# Altium schematic sheet TruckCape BeagleBone Headers v3.SchDoc
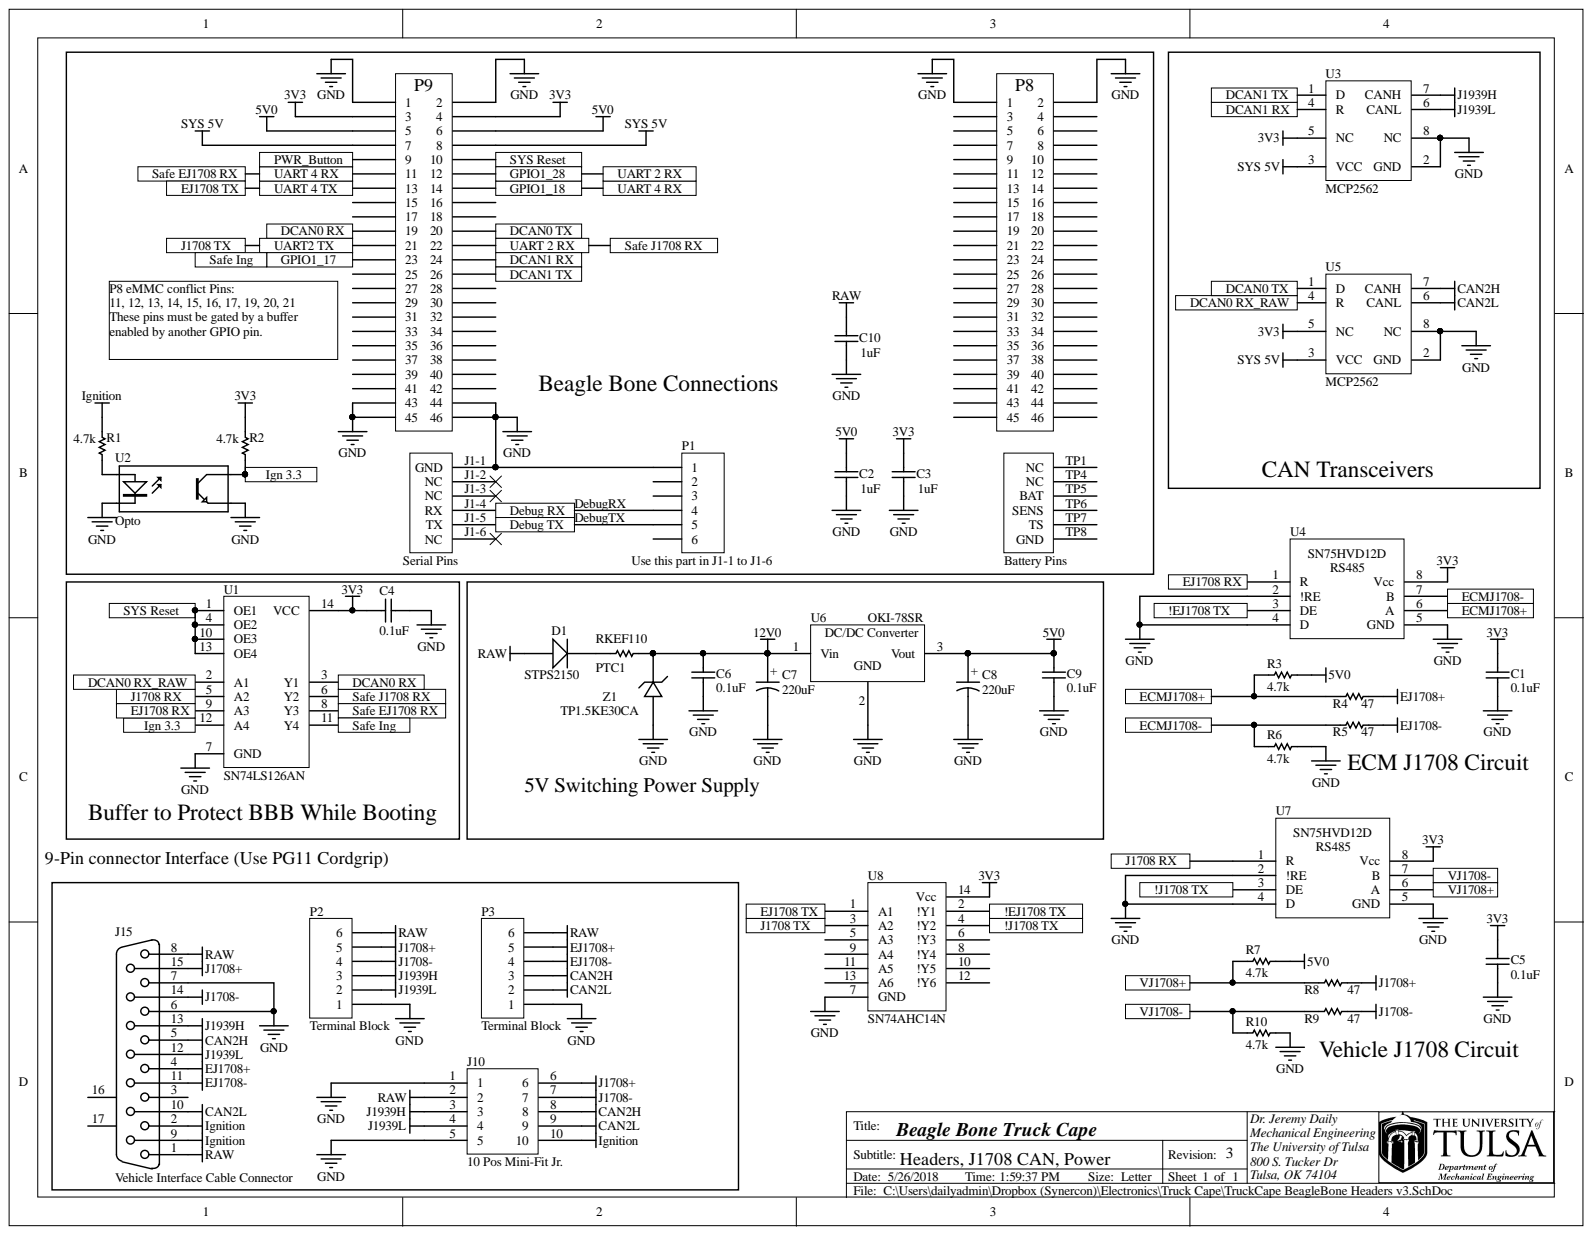
[diagram p0: TruckCape BeagleBone Headers v3.SchDoc]

## Parts
BeagleBoneBlack1  BeagleBoneBlack  [Beagleboneblack]  (value not drawn)
C1  0.1uF  [CAPR5-4X5]
C2  1uF  [CAPR5-4X5]
C3  1uF  [CAPR5-4X5]
C4  0.1uF  [CAPR5-4X5]
C5  0.1uF  [CAPR5-4X5]
C6  0.1uF  [CAPR5-4X5]
C7  220uF  [Elec. Cap 5 x 10mm]
C8  220uF  [Elec. Cap 5 x 10mm]
C9  0.1uF  [CAPR5-4X5]
C10  1uF  [CAPR5-4X5]
D1  STPS2150  [DO-41]
J10  10 Pos Mini-Fit Jr.  [10 pos Mini-Fit Jr. R/A Header]
J15  Vehicle Interface Cable Connector  [DB15]
P1  Use this part in J1-1 to J1-6  [HDR1X6]
P2  Terminal Block  [HDR1X6]
P3  Terminal Block  [HDR1X6]
PTC1  RKEF110  [PTC]
R1  4.7k  [Axial-0.4]
R2  4.7k  [Axial-0.4]
R3  4.7k  [Axial-0.4]
R4  47  [Axial-0.4]
R5  47  [Axial-0.4]
R6  4.7k  [Axial-0.4]
R7  4.7k  [Axial-0.4]
R8  47  [Axial-0.4]
R9  47  [Axial-0.4]
R10  4.7k  [Axial-0.4]
U1  SN74LS126AN  [N14A]
U2  Opto  [DIP-4]
U3  MCP2562  [SN65HVD232]
U4  SN75HVD12  [PDIP300-P8]
U5  MCP2562  [SN65HVD232]
U6  OKI-78SR  [OKI-78SR]
U7  SN75HVD12  [PDIP300-P8]
U8  SN74AHC14N  [N14A]
Z1  TP1.5KE30CA  [DIODE-0.7]

## Ports
!EJ1708 TX, !J1708 TX, DCAN0 RX, DCAN0 RX_RAW, DCAN0 TX, DCAN1 RX, DCAN1 TX, Debug RX, Debug TX, ECMJ1708+, ECMJ1708-, EJ1708 RX, EJ1708 TX, GPIO1_17, GPIO1_18, GPIO1_28, Ign 3.3, J1708 RX, J1708 TX, PWR_Button, Safe EJ1708 RX, Safe Ing, Safe J1708 RX, SYS Reset, UART2 TX, UART 2 RX, UART 4 RX, UART 4 TX, VJ1708+, VJ1708-

## Nets
3V3: BeagleBoneBlack1.3 BeagleBoneBlack1.4 C1.2 C3.1 C4.1 C5.2 R2.1 U1.14(VCC) U3.5(NC) U4.8(Vcc) U5.5(NC) U7.8(Vcc) U8.14(Vcc)
5V0: BeagleBoneBlack1.5 BeagleBoneBlack1.6 C2.1 C8.1 C9.2 R3.2 R7.2 U6.3
12V0: C6.2 C7.1 PTC1.2 U6.1 Z1.2
CAN2H: J10.8 J15.5 P3.3 U5.7(CANH)
CAN2L: J10.9 J15.10 P3.2 U5.6(CANL)
EJ1708+: J15.4 P3.5 R4.2
EJ1708-: J15.11 P3.4 R5.2
GND: BeagleBoneBlack1.1 BeagleBoneBlack1.2 BeagleBoneBlack1.43 BeagleBoneBlack1.44 BeagleBoneBlack1.45 BeagleBoneBlack1.46 BeagleBoneBlack1.J1-1 BeagleBoneBlack1.P1 BeagleBoneBlack1.P2 C1.1 C2.2 C3.2 C4.2 C5.1 C6.1 C7.2 C8.2 C9.1 C10.2 J10.1 J10.5 J15.6 J15.7 P1.1 P2.1 P3.1 R6.2 R10.2 U1.7(GND) U2.2 U2.3 U3.2(GND) U3.8(NC) U4.2(!RE) U4.4(D) U4.5(GND) U5.2(GND) U5.8(NC) U6.2 U7.2(!RE) U7.4(D) U7.5(GND) U8.7(GND) Z1.1
Ignition: J10.10 J15.2 J15.9 R1.1
J1708+: J10.6 J15.15 P2.5 R8.2
J1708-: J10.7 J15.14 P2.4 R9.2
J1939H: J10.3 J15.13 P2.3 U3.7(CANH)
J1939L: J10.4 J15.12 P2.2 U3.6(CANL)
RAW: C10.1 D1.1 J10.2 J15.1 J15.8 P2.6 P3.6
SYS 5V: BeagleBoneBlack1.7 BeagleBoneBlack1.8 U3.3(VCC) U5.3(VCC)
!EJ1708 TX: U4.3(DE) U8.2(!Y1) ; port !EJ1708 TX
!J1708 TX: U7.3(DE) U8.4(!Y2) ; port !J1708 TX
DCAN0 RX: BeagleBoneBlack1.19 U1.3(Y1) ; port DCAN0 RX
DCAN0 RX_RAW: U1.2(A1) U5.4(R) ; port DCAN0 RX_RAW
DCAN0 TX: BeagleBoneBlack1.20(DCAN0_TX) U5.1(D) ; port DCAN0 TX
DCAN1 RX: BeagleBoneBlack1.24(DCAN1_RX) U3.4(R) ; port DCAN1 RX
DCAN1 TX: BeagleBoneBlack1.26(DCAN1_TX) U3.1(D) ; port DCAN1 TX
DebugRX: BeagleBoneBlack1.J1-4(Debug_RX) P1.4 ; port Debug RX
DebugTX: BeagleBoneBlack1.J1-5(Debug_TX) P1.5 ; port Debug TX
EJ1708 RX: U1.9(A3) U4.1(R) ; port EJ1708 RX
EJ1708 TX: BeagleBoneBlack1.13 U8.1(A1) ; port EJ1708 TX, port UART 4 TX
GPIO1_17: BeagleBoneBlack1.23 U1.11(Y4) ; port GPIO1_17, port Safe Ing
GPIO1_18: BeagleBoneBlack1.11 BeagleBoneBlack1.14(GPIO1_18) U1.8(Y3) ; port GPIO1_18, port Safe EJ1708 RX, port UART 4 RX
GPIO1_28: BeagleBoneBlack1.12(GPIO1_28) BeagleBoneBlack1.22(UART_2_RX) U1.6(Y2) ; port GPIO1_28, port Safe J1708 RX, port UART 2 RX
Ign 3.3: R2.2 U1.12(A4) U2.4 ; port Ign 3.3
J1708 RX: U1.5(A2) U7.1(R) ; port J1708 RX
J1708 TX: BeagleBoneBlack1.21 U8.3(A2) ; port J1708 TX, port UART2 TX
PWR_Button: BeagleBoneBlack1.9 ; port PWR_Button
SYS Reset: BeagleBoneBlack1.10(SYS_Reset) U1.1(OE1) U1.4(OE2) U1.10(OE3) U1.13(OE4) ; port SYS Reset
NetD1_2: D1.2 PTC1.1
NetR1_2: R1.2 U2.1
NetR3_1: R3.1 R4.1 U4.6(A)
NetR5_1: R5.1 R6.1 U4.7(B)
NetR7_1: R7.1 R8.1 U7.6(A)
NetR9_1: R9.1 R10.1 U7.7(B)

Not connected: BeagleBoneBlack1 15 16 17 18 25 27 28 29 30 31 32 33 34 35 36 37 38 39 40 41 42 J1-2 J1-3 J1-6 P3 P4 P5 P6 P7 P8 P9 P10 P11 P12 P13 P14 P15 P16 P17 P18 P19 P20 P21 P22 P23 P24 P25 P26 P27 P28 P29 P30 P31 P32 P33 P34 P35 P36 P37 P38 P39 P40 P41 P42 P43 P44 P45 P46 TP1(NC) TP4(NC) TP5(BAT) TP6(SENS) TP7(TS) TP8(GND); J15 3 16 17; P1 2 3 6; U8 5(A3) 6(!Y3) 8(!Y4) 9(A4) 10(!Y5) 11(A5) 12(!Y6) 13(A6)

## Library data of the parts
BeagleBoneBlack1 = BeagleBoneBlack: 46 Positions Header, Breakaway Connector 0.100" (2.54mm) Through Hole Tin; Manufacturer: Sullins Connector Solutions; PartNumber: PREC023DAAN-RC; Component Kind: Standard; Library Name: BeagleBoneBlack.IntLib; Supplier 1: Digi-Key; Supplier Part Number 1: S2012EC-23-ND
C1 C4-C6 C9 = Cap: CAP CER 0.1UF 100V X7R RADIAL; Manufacturer: Vishay BC Components; PartNumber: K104K20X7RH53H5; Component Kind: Standard; Library Name: SSS Schematic Library.SchLib; Signal Integrity: Cap; Simulation: CAP; Supplier 1: Digi-Key; Supplier Part Number 1: BC5139-ND
C2 C3 C10 = Cap: CAP CER 1UF 100V X7R RADIAL; Manufacturer: Murata Electronics North America; PartNumber: RDER72A105K2M1H03A; Component Kind: Standard; Library Name: SSS Schematic Library.SchLib; Signal Integrity: Cap; Simulation: CAP; Supplier 1: Digi-Key; Supplier Part Number 1: 490-9198-1-ND
C7 C8 = Electrolytic Cap: 220µF 50V Aluminum Electrolytic Capacitors Radial, Can 175 mOhm @ 10kHz 5000 Hrs @ 105°C; Manufacturer: EPCOS (TDK); PartNumber: B41858C6227M000; Component Kind: Standard; Library Name: SSS Integrated Library.IntLib; Supplier 1: Digi-Key; Supplier Part Number 1: 495-6000-ND
D1 = Diode 1N4003: Diode Schottky 150V 2A Through Hole DO-15; Manufacturer: STMicroelectronics; Component Kind: Standard; Library Name: Miscellaneous Devices.IntLib; PartNumber: STPS2150; Signal Integrity: 1N4003; Simulation: 1N4003; Supplier 1: Digi-Key; Supplier Part Number 1: 497-3213-1-ND
J10 = 10 Pos Header: 10 Positions Header Connector 0.165" (4.20mm) Through Hole, Right Angle Tin; Manufacturer: Molex; Component Kind: Standard; Library Name: Molex Mini-Fit Jr.IntLib; PartNumber: 39-30-1100; Supplier 1: Digi-Key; Supplier Part Number 1: WM1355-ND
J15 = 788781-2: Receptacle Assembly, 15 Position, Right Angle, 8.08mm Series, Amplimite, with Screwlocks; Manufacturer: Amphenol FCI; PartNumber: D15S33E4GX00LF; Component Kind: Standard; Library Name: SSS Schematic Library.SchLib; Signal Integrity: D Subminiature; Supplier 1: Digi-Key; Supplier Part Number 1: 609-1499-ND
P1 = Header 6: CONN HEADER FEMALE 6POS .1" GOLD; Manufacturer: Sullins Connector Solutions; PartNumber: PPPC061LFBN-RC; Component Kind: Standard; Library Name: Miscellaneous Connectors.IntLib; Signal Integrity: Connector; Supplier 1: Digi-Key; Supplier Part Number 1: S7039-ND
P2 P3 = Header 6: 6 Position Wire to Board Terminal Block Horizontal with Board 0.100" (2.54mm) Through Hole; Manufacturer: TE Connectivity AMP Connectors; PartNumber: 282834-6; Component Kind: Standard; Library Name: Miscellaneous Connectors.IntLib; Signal Integrity: Connector; Supplier 1: Digi-Key; Supplier Part Number 1: A98337-ND
PTC1 = SMD Resistor: Polymeric PTC Resettable Fuse 60V 1.1A Ih Through Hole Radial, Disc; Manufacturer: Littelfuse Inc.; Component Kind: Standard; Library Name: SSS Schematic Library.SchLib; PartNumber: RKEF110; Supplier 1: Digi-Key; Supplier Part Number 1: RKEF110HF-ND
R1-R3 R6 R7 R10 = Chip Resistor: RES SMD 4.7K OHM 1% 1/10W 0603; Manufacturer: Yageo; PartNumber: CFR-25JB-52-4K7; Component Kind: Standard; Library Name: SSS Schematic Library.SchLib; Supplier 1: Digi-Key; Supplier Part Number 1: 4.7KQBK-ND
R4 R5 R8 R9 = Chip Resistor: 47 Ohms ±5% 0.25W, 1/4W Through Hole Resistor Axial  Carbon Film; Manufacturer: Yageo; PartNumber: CFR-25JB-52-47R; Component Kind: Standard; Library Name: SSS Schematic Library.SchLib; Supplier 1: Digi-Key; Supplier Part Number 1: 47QBK-ND
U1 = DM74LS126AN: Quad 3-State Buffer; Manufacturer: Texas Instruments; Component Kind: Standard; ComponentLink1Description: Manufacturer Link; ComponentLink1URL: http://www.fairchildsemi.com/; DatasheetVersion: Mar-1998; LatestRevisionDate: 29-May-2009; LatestRevisionNote: Component Body Added.; Library Name: FSC Logic Buffer Line Driver.IntLib; PackageDescription: DIP, 14-Pin, Row Spacing 7.62 mm, Pitch 2.54 mm; PackageReference: N14A; PackageVersion: 2000; PartNumber: SN74LS126AN; PCB3D: N14A; Published: 17-Jul-2002; Signal Integrity: DM74LS126AN; Simulation: 74LS126X4; Supplier 1: Digi-Key; Supplier Part Number 1: 296-3643-5-ND; Publisher: Altium Limited
U2 = Optoisolator1: 4-Pin Phototransistor Optocoupler; Component Kind: Standard; DatasheetVersion: Nov-2003; LatestRevisionDate: 04-Mar-2005; LatestRevisionNote: Sch pins 3 & 4 Swapped.; Library Name: Miscellaneous Devices.IntLib; PackageReference: DIP-4; Published: 24-Mar-1999; Publisher: Altium Limited
U3 U5 = SN65HVD232DR: 1/1 Transceiver Half CAN 8-PDIP; Manufacturer: Microchip Technology; PartNumber: MCP2562-E/P; Drivers Per Package: 1; Eco-Plan: Green (RoHS & no Sb/Br); ICC(Max)(mA): 17; Isolated: No; Rating: Catalog; Supply Voltage(s)(V): 3.3; Transient Pulse Protection(V): -25 to 25; Code_JEDEC: MS-012AA; Component Kind: Standard; ComponentLink1Description: Datasheet; ComponentLink1URL: http://www.ti.com/general/docs/lit/getliterature.tsp?genericPartNumber=SN65HVD232&fileType=pdf; DatasheetVersion: SLOS346K; Library Name: Texas Instruments Interface CAN.IntLib; Mounting Technology: Surface Mount; PackageDescription: 8-Pin Plastic Small Outline Integrated Circuit, 4.9 x 3.9 mm, Pitch 1.27 mm Pitch; PackageReference: D8; PackageVersion: revM, Jun-2011; Receivers Per Package: 1; SubFamily: CAN; Supplier 1: Digi-Key; Supplier 2: Digi-Key; Supplier 3: Digi-Key; Supplier 4: Newark; Supplier 5: Mouser; Supplier Part Number 1: MCP2562-E/P-ND; Supplier Part Number 2: 296-26344-1-ND; Supplier Part Number 3: 296-26344-6-ND; Supplier Part Number 4: 75C7753; Supplier Part Number 5: 595-SN65HVD232DR
U4 U7 = SN75HVD12D (3.3v RS485): IC 3.3V DIFF TXRX 8-SOIC; Manufacturer: Texas Instruments; PartNumber: SN75HVD12P; Component Kind: Standard; Library Name: SSS Schematic Library.SchLib; Supplier 1: Digi-Key; Supplier Part Number 1: SN75HVD12P
U6 = 7805: 5V DC/DC Converter (7805 replacement); Manufacturer: Murata Power Solutions Inc.; PartNumber: OKI-78SR-5/1.5-W36H-C; Component Kind: Standard; Library Name: SSS Integrated Library.IntLib; Supplier 1: Digi-Key; Supplier 2: Digi-Key; Supplier Part Number 1: 811-2692-ND; Supplier Part Number 2: 811-2692-ND
U8 = 74VHC14 Hex Inverter: Inverter IC 6 Channel Schmitt Trigger 14-PDIP; Manufacturer: Texas Instruments; Component Kind: Standard; Library Name: SSS Integrated Library.IntLib; PartNumber: SN74AHC14N; Supplier 1: Digi-Key; Supplier Part Number 1: 296-4550-5-ND
Z1 = Zener: TVS DIODE 25.6V 41.4V DO201; Manufacturer: Littelfuse Inc.; Component Kind: Standard; Library Name: SSS Integrated Library.IntLib; PartNumber: TP1.5KE30CA; Supplier 1: Digi-Key; Supplier Part Number 1: F7610CT-ND
